# Altium schematic sheet [02] - USB.SchDoc("[02] - USB")
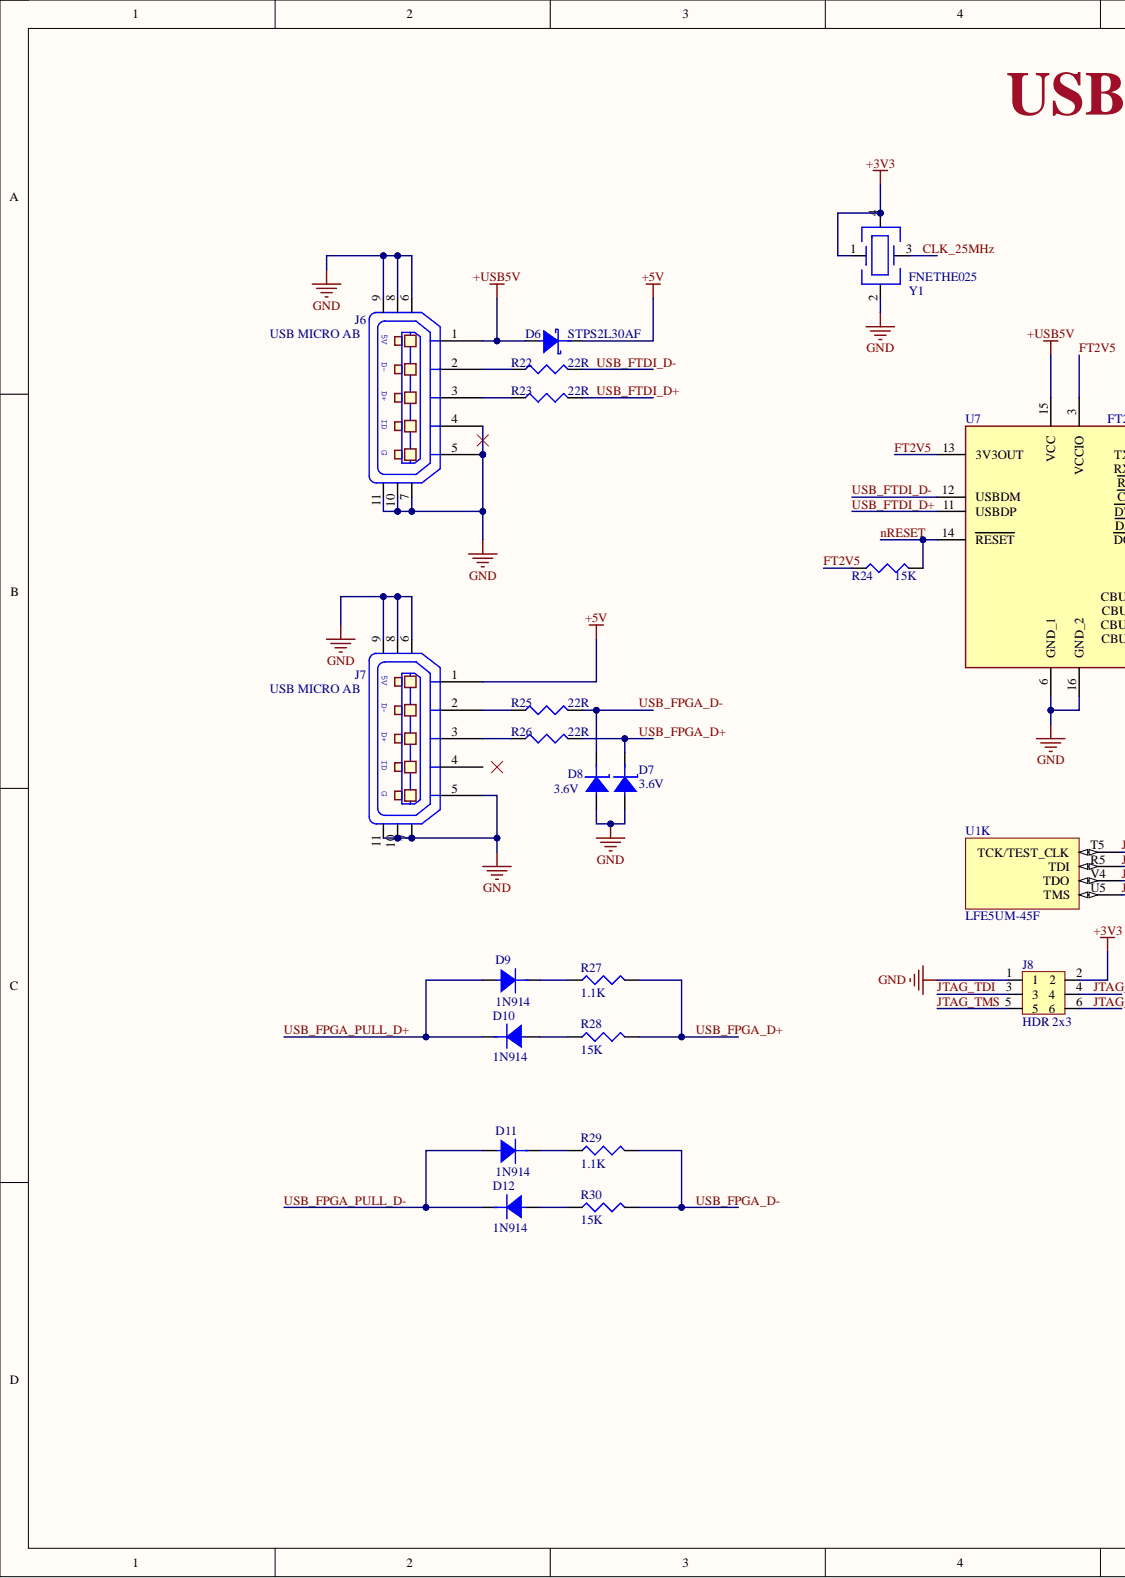
[diagram p0: [02] - USB.SchDoc, left]
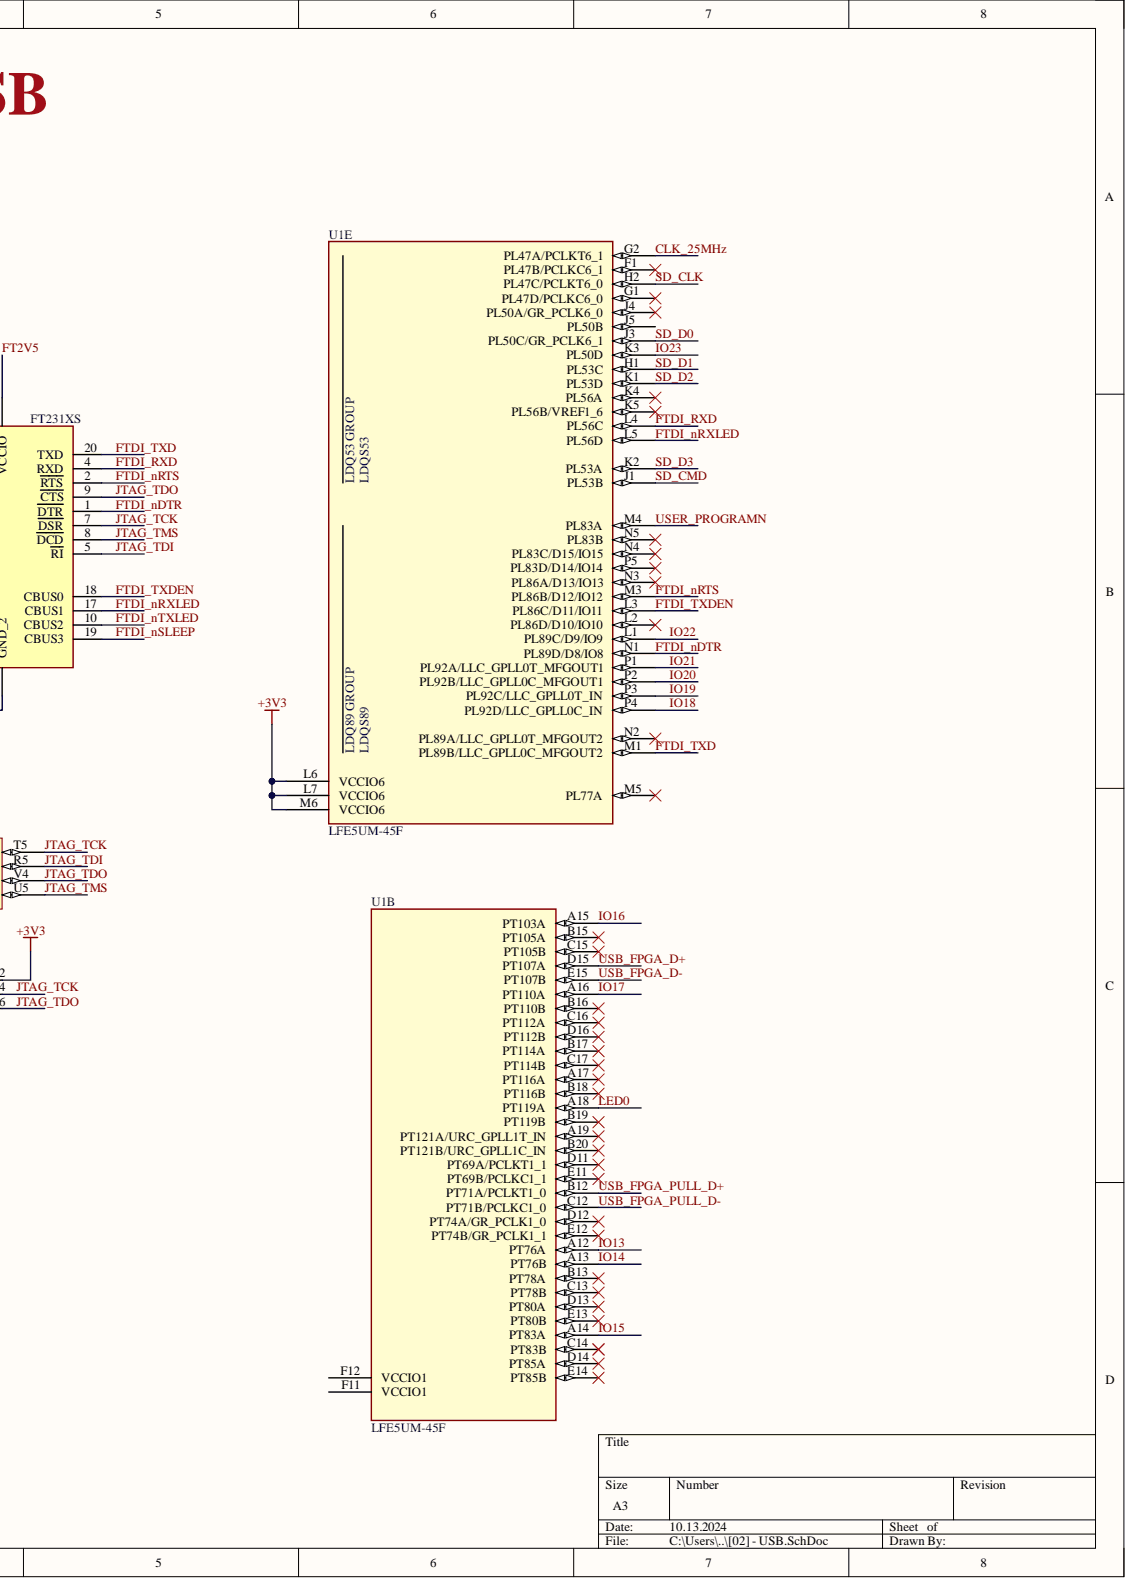
[diagram p1: [02] - USB.SchDoc, right]

## Parts
D6  STPS2L30AF  [SMA]
D7  3.6V  [SOD323]
D8  3.6V  [SOD323]
D9  1N914  [SOD323]
D10  1N914  [SOD323]
D11  1N914  [SOD323]
D12  1N914  [SOD323]
J6  USB MICRO AB  [USB_Micro_AB]
J7  USB MICRO AB  [USB_Micro_AB]
J8  HDR 2x3  [HDR_2x3_2.54MM]
R22  22R  [R0603]
R23  22R  [R0603]
R24  15K  [R0603]
R25  22R  [R0603]
R26  22R  [R0603]
R27  1.1K  [R0603]
R28  15K  [R0603]
R29  1.1K  [R0603]
R30  15K  [R0603]
U1  LFE5UM-45F  [LFE5BG381]  (parts B,E,K)
U7  FT231XS  [SOP64P599X175-20N]
Y1  FNETHE025  [TSOP440P690X140-4N]

## Nets
+3V3: J8.2 U1.L6(VCCIO6) U1.L7(VCCIO6) U1.M6(VCCIO6) Y1.1 Y1.4
+5V: D6.1 J7.1
+USB5V: D6.2 J6.1 U7.15(VCC)
GND: D7.2 D8.2 J6.4 J6.5 J6.6 J6.7 J6.8 J6.9 J6.10 J6.11 J7.5 J7.6 J7.7 J7.8 J7.9 J7.10 J7.11 J8.1 U7.6(GND_1) U7.16(GND_2) Y1.2
CLK_25MHz: U1.G2(PL47A/PCLKT6_1) Y1.3
FT2V5: R24.1 U7.3(VCCIO) U7.13(3V3OUT)
FTDI_nDTR: U1.N1(PL89D/D8/IO8) U7.1(DTR)
FTDI_nRTS: U1.M3(PL86B/D12/IO12) U7.2(RTS)
FTDI_nRXLED: U1.L5(PL56D) U7.17(CBUS1)
FTDI_nSLEEP: U7.19(CBUS3)
FTDI_nTXLED: U7.10(CBUS2)
FTDI_RXD: U1.L4(PL56C) U7.4(RXD)
FTDI_TXD: U1.M1(PL89B/LLC_GPLL0C_MFGOUT2) U7.20(TXD)
FTDI_TXDEN: U1.L3(PL86C/D11/IO11) U7.18(CBUS0)
IO13: U1.A12(PT76A)
IO14: U1.A13(PT76B)
IO15: U1.A14(PT83A)
IO16: U1.A15(PT103A)
IO17: U1.A16(PT110A)
IO18: U1.P4(PL92D/LLC_GPLL0C_IN)
IO19: U1.P3(PL92C/LLC_GPLL0T_IN)
IO20: U1.P2(PL92B/LLC_GPLL0C_MFGOUT1)
IO21: U1.P1(PL92A/LLC_GPLL0T_MFGOUT1)
IO22: U1.L1(PL89C/D9/IO9)
IO23: U1.K3(PL50D)
JTAG_TCK: J8.4 U1.T5(TCK/TEST_CLK) U7.7(DSR)
JTAG_TDI: J8.3 U1.R5(TDI) U7.5(RI)
JTAG_TDO: J8.6 U1.V4(TDO) U7.9(CTS)
JTAG_TMS: J8.5 U1.U5(TMS) U7.8(DCD)
LED0: U1.A18(PT119A)
nRESET: R24.2 U7.14(RESET)
SD_CLK: U1.H2(PL47C/PCLKT6_0)
SD_CMD: U1.J1(PL53B)
SD_D0: U1.J3(PL50C/GR_PCLK6_1)
SD_D1: U1.H1(PL53C)
SD_D2: U1.K1(PL53D)
SD_D3: U1.K2(PL53A)
USB_FPGA_D+: D7.1 R26.2 R27.2 R28.2 U1.D15(PT107A)
USB_FPGA_D-: D8.1 R25.2 R29.2 R30.2 U1.E15(PT107B)
USB_FPGA_PULL_D+: D9.2 D10.1 U1.B12(PT71A/PCLKT1_0)
USB_FPGA_PULL_D-: D11.2 D12.1 U1.C12(PT71B/PCLKC1_0)
USB_FTDI_D+: R23.2 U7.11(USBDP)
USB_FTDI_D-: R22.2 U7.12(USBDM)
USER_PROGRAMN: U1.M4(PL83A)
NetD9_1: D9.1 R27.1
NetD10_2: D10.2 R28.1
NetD11_1: D11.1 R29.1
NetD12_2: D12.2 R30.1
NetJ6_2: J6.2 R22.1
NetJ6_3: J6.3 R23.1
NetJ7_2: J7.2 R25.1
NetJ7_3: J7.3 R26.1

Not connected: J7 4; U1 A17(PT116A) A19(PT121A/URC_GPLL1T_IN) B13(PT78A) B15(PT105A) B16(PT110B) B17(PT114A) B18(PT116B) B19(PT119B) B20(PT121B/URC_GPLL1C_IN) C13(PT78B) C14(PT83B) C15(PT105B) C16(PT112A) C17(PT114B) D11(PT69A/PCLKT1_1) D12(PT74A/GR_PCLK1_0) D13(PT80A) D14(PT85A) D16(PT112B) E11(PT69B/PCLKC1_1) E12(PT74B/GR_PCLK1_1) E13(PT80B) E14(PT85B) F1(PL47B/PCLKC6_1) F11(VCCIO1) F12(VCCIO1) G1(PL47D/PCLKC6_0) J4(PL50A/GR_PCLK6_0) J5(PL50B) K4(PL56A) K5(PL56B/VREF1_6) L2(PL86D/D10/IO10) M5(PL77A) N2(PL89A/LLC_GPLL0T_MFGOUT2) N3(PL86A/D13/IO13) N4(PL83C/D15/IO15) N5(PL83B) P5(PL83D/D14/IO14)

## Library data of the parts
D6 = STPS2L30AF: DIODE SCHOTTKY 30V 2A SMA; Manufacturer: STMicroelectronics; Element Configuration: Single; Forward Voltage: 530mV; Max Forward Surge Current (Ifsm): 75A; Max Reverse Leakage Current: 200uA; Average Rectified Current: 2A; Case/Package: SMA; Component Kind: Standard; ComponentLink1Description: http://www.st.com/content/ccc/resource/technical/document/datasheet/62/6b/2e/7f/34/cb/43/55/CD00001633.pdf/files/CD00001633.pdf/jcr:content/translations/en.CD00001633.pdf?src-supplier=Digi-Key; ComponentLink1URL: http://www.st.com/content/ccc/resource/technical/document/datasheet/62/6b/2e/7f/34/cb/43/55/CD00001633.pdf/files/CD00001633.pdf/jcr:content/translations/en.CD00001633.pdf?src-supplier=Digi-Key; ComponentLink2Description: http://www.st.com/st-web-ui/static/active/en/resource/technical/document/datasheet/CD00001633.pdf?src-supplier=Future+Electronics; ComponentLink2URL: http://www.st.com/st-web-ui/static/active/en/resource/technical/document/datasheet/CD00001633.pdf?src-supplier=Future+Electronics; ComponentLink3Description: http://www.st.com/st-web-ui/static/active/en/resource/technical/document/datasheet/CD00001633.pdf?src-supplier=Mouser; ComponentLink3URL: http://www.st.com/st-web-ui/static/active/en/resource/technical/document/datasheet/CD00001633.pdf?src-supplier=Mouser; Forward Current: 2A; Lead Free: Lead Free; Library Name: ECP5_V2.SchLib; Manufacturer Part Number: STPS2L30AF; Max Operating Temperature: 150°C; Max Repetitive Reverse Voltage (Vrrm): 30V; Max Reverse Voltage (DC): 30V; Max Surge Current: 75A; Min Operating Temperature: -65°C; Mount: Surface Mount; Number of Pins: 2; Package Quantity: 1; Packaging: Tape and Reel; Peak Non-Repetitive Surge Current: 75A; Peak Reverse Current: 200uA; Pricing 1: 1=0.45, 10=0.386, 25=0.3604, 100=0.2678, 250=0.2544, 500=0.2091, 1000=0.16995, 2500=0.15965, 5000=0.1442 (USD); Radiation Hardening: No; REACH SVHC: No SVHC; Stock 1: 8523; Supplier 1: Digi-Key; Supplier Part Number 1: 497-12310-6-ND
D7 D8 = BZT52C3V6S-TP: Diode Zener Single 3.6V 5% 200mW 2-Pin SOD-323 T/R; Manufacturer: MCC; Impedance: 90R; Max Reverse Leakage Current: 15uA; Test Current: 5mA; Tolerance: 6%; Component Kind: Standard; ComponentLink1Description: http://www.mccsemi.com/up_pdf/BZT52C2V4S~BZT52C39S(200mW)(SOD323).pdf?src-supplier=Digi-Key; ComponentLink1URL: http://www.mccsemi.com/up_pdf/BZT52C2V4S~BZT52C39S(200mW)(SOD323).pdf?src-supplier=Digi-Key; ComponentLink2Description: http://www.mouser.com/ds/2/258/BZT52C2V4S~BZT52C39S(200mW)(SOD323)-479732.pdf?src-supplier=Mouser; ComponentLink2URL: http://www.mouser.com/ds/2/258/BZT52C2V4S~BZT52C39S(200mW)(SOD323)-479732.pdf?src-supplier=Mouser; ComponentLink3Description: http://components.arrow.com/part/dataview/45991456S304003N2022?src-supplier=Arrow; ComponentLink3URL: http://components.arrow.com/part/dataview/45991456S304003N2022?src-supplier=Arrow; Element Configuration: Single; Lead Free: Lead Free; Library Name: ECP5_V2.SchLib; Manufacturer Part Number: BZT52C3V6S-TP; Max Operating Temperature: 175°C; Max Power Dissipation: 200mW; Min Operating Temperature: -65°C; Mount: Surface Mount; Number of Pins: 2; Package Quantity: 3000; Packaging: Tape and Reel; Power Dissipation: 200mW; Power Rating: 200mW; Pricing 1: 1=0.26, 10=0.215, 25=0.18, 100=0.088, 250=0.0866, 500=0.0734, 1000=0.051 (USD); Stock 1: 5023; Supplier 1: Digi-Key; Supplier Part Number 1: BZT52C3V6S-TPMSDKR-ND; Voltage Rating (DC): 3.6V; Voltage Tolerance: 5%; Zener Voltage: 3.6V
D9-D12 = 1N914BWS: ON SEMICONDUCTOR/FAIRCHILD - 1N914BWS. - SMALL SIGNAL DIODE; Average Rectified Current: 150mA; Element Configuration: Single; Forward Voltage: 1V; Max Forward Surge Current (Ifsm): 4A; Max Repetitive Reverse Voltage (Vrrm): 75V; Peak Reverse Current: 5uA; Power Dissipation: 200mW; Recovery Time: 4ns; Component Kind: Standard; ComponentLink1Description: https://www.fairchildsemi.com/datasheets/1N/1N4148WS.pdf?src-supplier=Digi-Key; ComponentLink1URL: https://www.fairchildsemi.com/datasheets/1N/1N4148WS.pdf?src-supplier=Digi-Key; ComponentLink2Description: https://www.onsemi.com/pub/Collateral/1N914BWS-D.pdf?src-supplier=Digi-Key; ComponentLink2URL: https://www.onsemi.com/pub/Collateral/1N914BWS-D.pdf?src-supplier=Digi-Key; ComponentLink3Description: http://www.fairchildsemi.com/ds/1N/1N914BWS.pdf?src-supplier=Future+Electronics; ComponentLink3URL: http://www.fairchildsemi.com/ds/1N/1N914BWS.pdf?src-supplier=Future+Electronics; ComponentLink4Description: http://www.farnell.com/datasheets/1771770.pdf?src-supplier=Element14; ComponentLink4URL: http://www.farnell.com/datasheets/1771770.pdf?src-supplier=Element14; ComponentLink5Description: https://www.fairchildsemi.com/datasheets/1N/1N914BWS.pdf?src-supplier=Avnet; ComponentLink5URL: https://www.fairchildsemi.com/datasheets/1N/1N914BWS.pdf?src-supplier=Avnet; ComponentLink6Description: http://www.fairchildsemi.com/ds/1N/1N914BWS.pdf?src-supplier=Avnet+Europe; ComponentLink6URL: http://www.fairchildsemi.com/ds/1N/1N914BWS.pdf?src-supplier=Avnet+Europe; Contact Plating: Tin,Matte; Forward Current: 150mA; Height: 1mm; Lead Free: Lead Free; Length: 1.8mm; Library Name: ECP5_V2.SchLib; Manufacturer: ON Semiconductor / Fairchild; Manufacturer Part Number: 1N914BWS; Max Operating Temperature: 150°C; Max Reverse Voltage (DC): 75V; Min Operating Temperature: -55°C; Mount: Surface Mount; Number of Pins: 2; Package Quantity: 1; Packaging: Tape and Reel; Pricing 1: 1=0.16, 10=0.147, 25=0.14, 100=0.0616, 250=0.05912, 500=0.04838, 1000=0.0336 (USD); Radiation Hardening: No; REACH SVHC: No SVHC; Reverse Recovery Time: 4ns; Reverse Voltage: 75V; Stock 1: 133438; Supplier 1: Digi-Key; Supplier Part Number 1: 1N914BWSDKR-ND; Weight: 0.0276g; Width: 1.35mm
J6 J7 = 0475890001: CONN RCPT MICRO USB AB R/A SMD; Manufacturer: Molex Inc; .Checked PCB: MM; Connector Type: USB - micro AB; Gender: Receptacle; Number of Contacts: 5; Category: Connectors, Interconnects; Component Kind: Standard; ComponentLink1Description: http://www.molex.com/pdm_docs/sd/475890001_sd.pdf; ComponentLink1URL: http://www.molex.com/pdm_docs/sd/475890001_sd.pdf; Features: Board Guide, Shielded; Ingress Protection: -; Library Name: ECP5_V2.SchLib; Manufacturer Part Number: 0475890001; Mounting Type: Surface Mount, Right Angle, Horizontal; Packaging: Cut Tape (CT); Pricing 1: 1=0.81, 10=0.764, 25=0.6872, 50=0.6108, 100=0.5854, 250=0.53452, 500=0.50908 (USD); RoHS: RoHS Compliant; Specifications: -; Stock 1: 12754; Supplier 1: Digi-Key; Supplier Part Number 1: WM17143CT-ND; .Checked SCH: MM; .Creator: MM
J8 = 67997-106HLF: CONN HEADER VERT 6POS 2.54MM; Manufacturer: Amphenol FCI; Connector Type: Male Pin; Contact Post Length: 3.05mm; Current Rating: 3A; Gender: Male; Insulation Resistance: 5GR; Max Voltage Rating (AC): 1.5kV; Number of Contacts: 6; Row Spacing: 0.1inch; Color: Black; Component Kind: Standard; ComponentLink1Description: https://cdn.amphenol-icc.com/media/wysiwyg/files/drawing/67996.pdf?src-supplier=Avnet; ComponentLink1URL: https://cdn.amphenol-icc.com/media/wysiwyg/files/drawing/67996.pdf?src-supplier=Avnet; ComponentLink2Description: https://cdn.amphenol-icc.com/media/wysiwyg/files/drawing/67996.pdf?src-supplier=Digi-Key; ComponentLink2URL: https://cdn.amphenol-icc.com/media/wysiwyg/files/drawing/67996.pdf?src-supplier=Digi-Key; ComponentLink3Description: https://cdn.amphenol-icc.com/media/wysiwyg/files/drawing/67996.pdf?src-supplier=Mouser; ComponentLink3URL: https://cdn.amphenol-icc.com/media/wysiwyg/files/drawing/67996.pdf?src-supplier=Mouser; ComponentLink4Description: https://cdn.amphenol-icc.com/media/wysiwyg/files/drawing/67996.pdf?src-supplier=Avnet+Europe; ComponentLink4URL: https://cdn.amphenol-icc.com/media/wysiwyg/files/drawing/67996.pdf?src-supplier=Avnet+Europe; Contact Gender: Male; Contact Material: Bronze; Contact Mating Length: 0.23inch; Contact Plating: Gold,GXT; Depth: 4.83mm; Flammability Rating: UL94 V-0; Housing Color: Black; Housing Material: Thermoplastic; Lead Free: Lead Free; Length: 7.62mm; Library Name: ECP5_V2.SchLib; Manufacturer Part Number: 67997-106HLF; Max Operating Temperature: 125°C; Min Operating Temperature: -65°C; Mount: Through Hole; Number of Positions: 6; Number of Rows: 2; Orientation: Straight; Package Quantity: 100; Packaging: Bulk; Pitch: 2.54mm; Pricing 1: 1=1.73, 10=1.568, 100=1.3436, 500=1.11966, 1000=0.9597, 5000=0.85093, 10000=0.83174 (USD); Radiation Hardening: No; REACH SVHC: No SVHC; Stock 1: 906; Supplier 1: Digi-Key; Supplier Part Number 1: 609-3393-ND; Termination: Through Hole; Voltage Rating: 1.5kV
R22 R23 R25 R26 = RC0603FR-0722RL: Res Thick Film 0603 22 Ohm 1% 0.1W(1/10W) ±100ppm/C Pad SMD T/R; Manufacturer: Yageo; Max Power Dissipation: 100mW; Temperature Coefficient: 100ppm/°C; Voltage Rating: 50V; Case Code (Imperial): 0603; Case Code (Metric): 1608; Case/Package: 0603; Component Kind: Standard; ComponentLink1Description: http://www.yageo.com/documents/recent/PYu-RC_Group_51_RoHS_L_10.pdf?src-supplier=Digi-Key; ComponentLink1URL: http://www.yageo.com/documents/recent/PYu-RC_Group_51_RoHS_L_10.pdf?src-supplier=Digi-Key; ComponentLink2Description: http://documents.rs-components.com/techlib://itc_doclist_GB.htm?stockno=5050369&src-supplier=RSComponents; ComponentLink2URL: http://documents.rs-components.com/techlib://itc_doclist_GB.htm?stockno=5050369&src-supplier=RSComponents; ComponentLink3Description: http://www.farnell.com/datasheets/2167052.pdf?src-supplier=Element14; ComponentLink3URL: http://www.farnell.com/datasheets/2167052.pdf?src-supplier=Element14; ComponentLink4Description: http://www.farnell.com/datasheets/443971.pdf?src-supplier=Element14; ComponentLink4URL: http://www.farnell.com/datasheets/443971.pdf?src-supplier=Element14; Composition: Thick Film; Depth: 0.8mm; Features: Moisture Resistant; Height: 0.022inch; Lead Free: Lead Free; Length: 1.6mm; Library Name: ECP5_V2.SchLib; Manufacturer Part Number: RC0603FR-0722RL; Max Operating Temperature: 155°C; Military Standard: Not; Min Operating Temperature: -55°C; Number of Pins: 2; Number of Terminations: 2; Package Quantity: 1; Packaging: Tape and Reel; Power Rating: 100mW; Pricing 1: 1=0.1, 10=0.023, 100=0.0094, 1000=0.00421, 2500=0.00366 (USD); REACH SVHC: No SVHC; Resistance: 22R; Stock 1: 736588; Supplier 1: Digi-Key; Supplier Part Number 1: 311-22.0HRCT-ND; Termination: SMD/SMT; Tolerance: 1%; Voltage Rating (DC): 50V; Width: 0.031inch
R24 R28 R30 = RC0603FR-0715KL: Res Thick Film 0603 15K Ohm 1% 1/10W ?100ppm/?C Molded SMD Paper T/R; Manufacturer: Yageo; Max Power Dissipation: 100mW; Resistance: 15kR; Temperature Coefficient: 100ppm/°C; Voltage Rating: 50V; Case Code (Imperial): 0603; Case Code (Metric): 1608; Case/Package: 0603; Component Kind: Standard; ComponentLink1Description: http://www.yageo.com/documents/recent/PYu-RC_Group_51_RoHS_L_10.pdf?src-supplier=Digi-Key; ComponentLink1URL: http://www.yageo.com/documents/recent/PYu-RC_Group_51_RoHS_L_10.pdf?src-supplier=Digi-Key; ComponentLink2Description: http://www.yageo.com/documents/recent/PYu-RC_Group_51_RoHS_L_9.pdf?src-supplier=Digi-Key; ComponentLink2URL: http://www.yageo.com/documents/recent/PYu-RC_Group_51_RoHS_L_9.pdf?src-supplier=Digi-Key; ComponentLink3Description: https://www.verical.com/server-webapp/html/getDatasheet?partID=327895&src-supplier=Verical; ComponentLink3URL: https://www.verical.com/server-webapp/html/getDatasheet?partID=327895&src-supplier=Verical; Composition: Thick Film; Depth: 0.8mm; Features: Moisture Resistant; Height: 0.022inch; Lead Free: Lead Free; Length: 1.6mm; Library Name: ECP5_V2.SchLib; Manufacturer Part Number: RC0603FR-0715KL; Max Operating Temperature: 155°C; Military Standard: Not; Min Operating Temperature: -55°C; Number of Pins: 2; Number of Terminations: 2; Package Quantity: 1; Packaging: Tape and Reel; Power Rating: 100mW; Pricing 1: 1=0.1, 10=0.023, 100=0.0094, 1000=0.00421, 2500=0.00366 (USD); REACH SVHC: Unknown; Stock 1: 3342455; Supplier 1: Digi-Key; Supplier Part Number 1: 311-15.0KHRCT-ND; Termination: SMD/SMT; Tolerance: 1%; Voltage Rating (DC): 50V; Width: 0.031inch
R27 R29 = RC0603FR-071K1L: RES SMD 1.1K OHM 1% 1/10W 0603; Manufacturer: Yageo; Max Power Dissipation: 100mW; Resistance: 1.1kR; Temperature Coefficient: 100ppm/°C; Voltage Rating: 50V; Case Code (Imperial): 0603; Case Code (Metric): 1608; Case/Package: 0603; Component Kind: Standard; ComponentLink1Description: http://www.yageo.com/documents/recent/PYu-RC_Group_51_RoHS_L_10.pdf?src-supplier=Digi-Key; ComponentLink1URL: http://www.yageo.com/documents/recent/PYu-RC_Group_51_RoHS_L_10.pdf?src-supplier=Digi-Key; ComponentLink2Description: http://www.yageo.com/documents/recent/PYu-RC_Group_51_RoHS_L_9.pdf?src-supplier=Digi-Key; ComponentLink2URL: http://www.yageo.com/documents/recent/PYu-RC_Group_51_RoHS_L_9.pdf?src-supplier=Digi-Key; Composition: Thick Film; Depth: 0.8mm; Features: Moisture Resistant; Height: 0.022inch; Lead Free: Lead Free; Length: 1.6mm; Library Name: ECP5_V2.SchLib; Manufacturer Part Number: RC0603FR-071K1L; Max Operating Temperature: 155°C; Military Standard: Not; Min Operating Temperature: -55°C; Number of Pins: 2; Number of Terminations: 2; Package Quantity: 1; Packaging: Tape and Reel; Power Rating: 100mW; Pricing 1: 1=0.1, 10=0.023, 100=0.0094, 1000=0.00421, 2500=0.00366 (USD); REACH SVHC: No SVHC; Stock 1: 534181; Supplier 1: Digi-Key; Supplier Part Number 1: 311-1.10KHRDKR-ND; Termination: SMD/SMT; Tolerance: 1%; Voltage Rating (DC): 50V; Width: 0.031inch
U1 = LFE5UM-45F-6BG381C: ECP5 Series 44 K LUTs 203 I/O 1.1 V Slow Surface Mount FPGA - CABGA-381; Manufacturer: Lattice Semiconductor; Number of I/Os: 203; Number of Logic Blocks (LABs): 11000; Number of Logic Elements/Cells: 44000; RAM Size: 1990656b; Case/Package: FBGA; Component Kind: Standard; ComponentLink1Description: http://www.latticesemi.com/~/media/LatticeSemi/Documents/DataSheets/ECP5/DS1044.pdf?src-supplier=Digi-Key; ComponentLink1URL: http://www.latticesemi.com/~/media/LatticeSemi/Documents/DataSheets/ECP5/DS1044.pdf?src-supplier=Digi-Key; Lead Free: Lead Free; Library Name: ECP5_V2.SchLib; Manufacturer Part Number: LFE5UM-45F-6BG381C; Max Operating Temperature: 85°C; Min Operating Temperature: 0°C; Mount: Surface Mount; Pricing 1: 90=19.13967 (USD); Stock 1: 0; Supplier 1: Digi-Key; Supplier Part Number 1: 220-1994-ND
U7 = FT231XS-R: FTDI - FT231XS-R - USB Interface, Transceiver, USB 2.0, 2.97 V, 5.5 V, SSOP, 20 RoHS Compliant: Yes; Data Rate: 12Mbps; Interface: UART,USB; Max Supply Voltage: 5.5V; Min Supply Voltage: 2.97V; Number of Channels: 1; Operating Supply Current: 8mA; Operating Supply Voltage: 5V; Case/Package: SSOP; Component Kind: Standard; ComponentLink1Description: http://uk.rs-online.com/webdocs/1102/0900766b811020d3.pdf?src-supplier=RSComponents; ComponentLink1URL: http://uk.rs-online.com/webdocs/1102/0900766b811020d3.pdf?src-supplier=RSComponents; ComponentLink2Description: https://media.digikey.com/pdf/Data%20Sheets/FTDI%20Chip%20PDFs/X-Chip_Series_Errata.pdf?src-supplier=Digi-Key; ComponentLink2URL: https://media.digikey.com/pdf/Data%20Sheets/FTDI%20Chip%20PDFs/X-Chip_Series_Errata.pdf?src-supplier=Digi-Key; ComponentLink3Description: http://www.ftdichip.com/Support/Documents/DataSheets/ICs/DS_FT231X.pdf?src-supplier=Digi-Key; ComponentLink3URL: http://www.ftdichip.com/Support/Documents/DataSheets/ICs/DS_FT231X.pdf?src-supplier=Digi-Key; ComponentLink4Description: http://www.alliedelec.com/images/products/datasheets/bm/FTDI/70403932.pdf?src-supplier=Allied+Electronics; ComponentLink4URL: http://www.alliedelec.com/images/products/datasheets/bm/FTDI/70403932.pdf?src-supplier=Allied+Electronics; Height: 0.059inch; Length: 8.74mm; Library Name: ECP5_V2.SchLib; Manufacturer: FTDI; Manufacturer Part Number: FT231XS-R; Max Operating Temperature: 85°C; Min Operating Temperature: -40°C; Mount: Surface Mount; Number of Pins: 20; Package Quantity: 2000; Packaging: Tape and Reel; Pricing 1: 1=2.12, 25=2.05, 100=1.98, 250=1.78, 500=1.6, 1000=1.55 (USD); Radiation Hardening: No; Stock 1: 6035; Supplier 1: Digi-Key; Supplier Part Number 1: 768-1129-6-ND; Weight: 0.016oz; Width: 0.157inch
Y1 = FNETHE025: SMD Crystal Oscillator 25MHz 3.3V 15pF 4-Pin CSMD; Manufacturer: Diodes; Frequency Stability: 25ppm; Max Supply Current: 15mA; Max Supply Voltage: 3.63V; Min Supply Voltage: 2.97V; Case/Package: CSMD; Component Kind: Standard; ComponentLink1Description: http://www.pericom.com/assets/Datasheets/FNETHE025.pdf?src-supplier=Avnet+Europe; ComponentLink1URL: http://www.pericom.com/assets/Datasheets/FNETHE025.pdf?src-supplier=Avnet+Europe; ComponentLink2Description: https://www.verical.com/server-webapp/html/getDatasheet?partID=362555&src-supplier=Verical; ComponentLink2URL: https://www.verical.com/server-webapp/html/getDatasheet?partID=362555&src-supplier=Verical; ComponentLink3Description: http://www.pericom.com/assets/Datasheets/FNETHE025-Rev-E.pdf?src-supplier=Future+Electronics; ComponentLink3URL: http://www.pericom.com/assets/Datasheets/FNETHE025-Rev-E.pdf?src-supplier=Future+Electronics; ComponentLink4Description: https://www.diodes.com/assets/Datasheets/FNETHE025.pdf?src-supplier=Digi-Key; ComponentLink4URL: https://www.diodes.com/assets/Datasheets/FNETHE025.pdf?src-supplier=Digi-Key; ComponentLink5Description: https://media.digikey.com/pdf/Data%20Sheets/Diodes%20PDFs/FNETHE025.pdf?src-supplier=Digi-Key; ComponentLink5URL: https://media.digikey.com/pdf/Data%20Sheets/Diodes%20PDFs/FNETHE025.pdf?src-supplier=Digi-Key; ComponentLink6Description: http://www.pericom.com/assets/Datasheets/FNETHE025.pdf?src-supplier=Avnet; ComponentLink6URL: http://www.pericom.com/assets/Datasheets/FNETHE025.pdf?src-supplier=Avnet; Depth: 5mm; Frequency: 25MHz; Height: 0.061inch; Lead Free: Lead Free; Length: 7mm; Library Name: ECP5_V2.SchLib; Load Capacitance: 15pF; Manufacturer Part Number: FNETHE025; Max Operating Temperature: 70°C; Min Operating Temperature: -20°C; Mount: Surface Mount; Number of Pins: 4; Operating Supply Voltage: 3.3V; Package Quantity: 1000; Packaging: Tape and Reel; Pricing 1: 1=1.1, 10=0.97, 50=0.9068, 100=0.8014, 500=0.75924 (USD); Radiation Hardening: No; Stock 1: 7023; Supplier 1: Digi-Key; Supplier Part Number 1: FNETHE025DKR-ND; Termination: SMD/SMT; Width: 0.197inch
